# Altium schematic sheet PWM_Expander.SchDoc
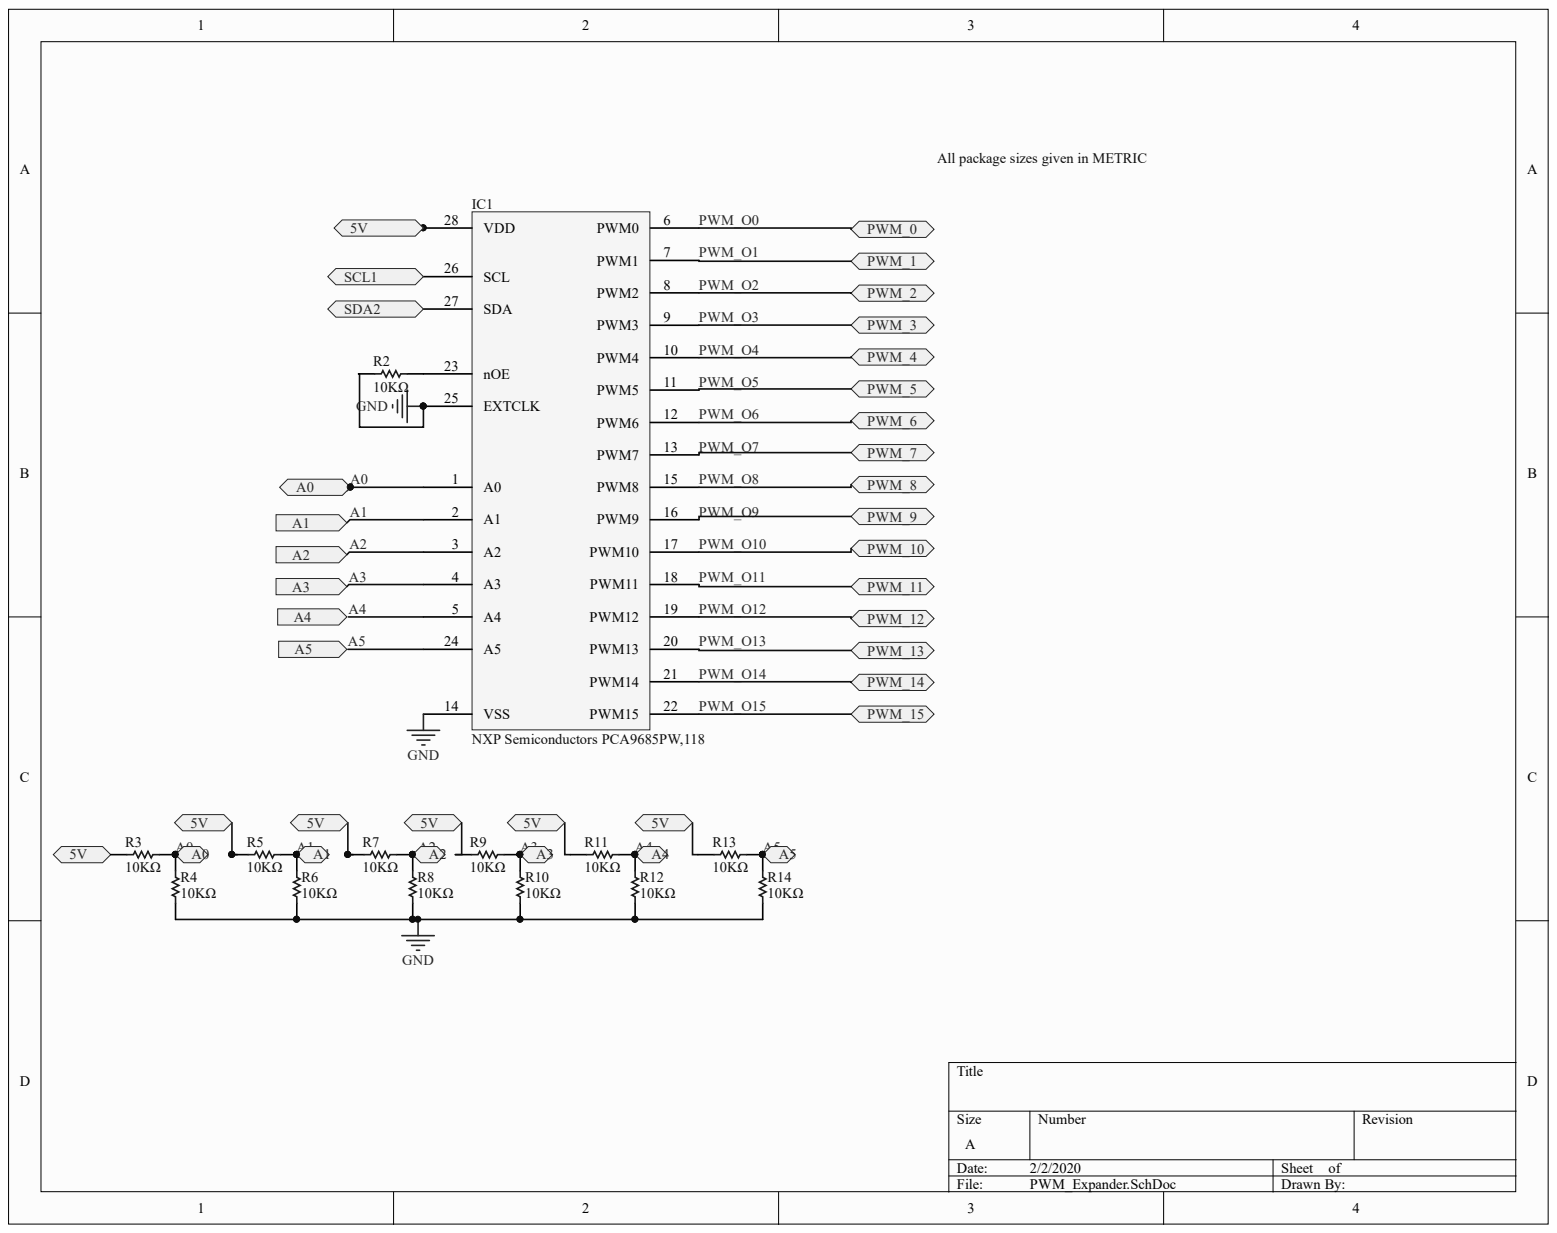
[diagram p0: PWM_Expander.SchDoc]

## Parts
IC1  NXP Semiconductors PCA9685PW,118  Manufacturer Part Number: PCA9685PW,118  [SOP28]
R2  10KΩ  [RESC3225X60X45NL20T20]
R3  10KΩ  [RESC3225X60X45NL20T20]
R4  10KΩ  [RESC3225X60X45NL20T20]
R5  10KΩ  [RESC3225X60X45NL20T20]
R6  10KΩ  [RESC3225X60X45NL20T20]
R7  10KΩ  [RESC3225X60X45NL20T20]
R8  10KΩ  [RESC3225X60X45NL20T20]
R9  10KΩ  [RESC3225X60X45NL20T20]
R10  10KΩ  [RESC3225X60X45NL20T20]
R11  10KΩ  [RESC3225X60X45NL20T20]
R12  10KΩ  [RESC3225X60X45NL20T20]
R13  10KΩ  [RESC3225X60X45NL20T20]
R14  10KΩ  [RESC3225X60X45NL20T20]

## Ports
5V, A0, A1, A2, A3, A4, A5, PWM_0, PWM_1, PWM_2, PWM_3, PWM_4, PWM_5, PWM_6, PWM_7, PWM_8, PWM_9, PWM_10, PWM_11, PWM_12, PWM_13, PWM_14, PWM_15, SCL1, SDA2

## Nets
GND: IC1.14(VSS) IC1.25(EXTCLK) R2.1 R4.2 R6.2 R8.2 R10.2 R12.2 R14.2
5V: IC1.28(VDD) R3.1 R5.1 R7.1 R9.1 R11.1 R13.1 ; port 5V
A0: IC1.1(A0) R3.2 R4.1 ; port A0
A1: IC1.2(A1) R5.2 R6.1 ; port A1
A2: IC1.3(A2) R7.2 R8.1 ; port A2
A3: IC1.4(A3) R9.2 R10.1 ; port A3
A4: IC1.5(A4) R11.2 R12.1 ; port A4
A5: IC1.24(A5) R13.2 R14.1 ; port A5
PWM_O0: IC1.6(PWM0) ; port PWM_0
PWM_O1: IC1.7(PWM1) ; port PWM_1
PWM_O2: IC1.8(PWM2) ; port PWM_2
PWM_O3: IC1.9(PWM3) ; port PWM_3
PWM_O4: IC1.10(PWM4) ; port PWM_4
PWM_O5: IC1.11(PWM5) ; port PWM_5
PWM_O6: IC1.12(PWM6) ; port PWM_6
PWM_O7: IC1.13(PWM7) ; port PWM_7
PWM_O8: IC1.15(PWM8) ; port PWM_8
PWM_O9: IC1.16(PWM9) ; port PWM_9
PWM_O10: IC1.17(PWM10) ; port PWM_10
PWM_O11: IC1.18(PWM11) ; port PWM_11
PWM_O12: IC1.19(PWM12) ; port PWM_12
PWM_O13: IC1.20(PWM13) ; port PWM_13
PWM_O14: IC1.21(PWM14) ; port PWM_14
PWM_O15: IC1.22(PWM15) ; port PWM_15
SCL1: IC1.26(SCL) ; port SCL1
SDA2: IC1.27(SDA) ; port SDA2
NetIC1_23: IC1.23(nOE) R2.2

## Library data of the parts
IC1 = CMP-d7fd1e432ce0083a-4: PCA9685 Series 5.5V 25 mA 1 MHz 12-bit PWM Fm+I2C-Bus LED Controller - TSSOP-28; Component Kind: Standard; Library Name: CommunityVault; Mounting Style: Surface Mount; Packaging: Tape & Reel (TR); RoHS: Compliant
R2-R14 = CMP-1860323-2: RES SMD 10 OHM 1% 1/2W 1210; Manufacturer Part Number: CRCW121010R0FKEA; Lead-Free Status: Lead Free; Power Rating: 0.5 W; Resistance: 10.0 Ω; Voltage Rating (DC): 200.0 V; Case/Package: 1210; Component Kind: Standard; Library Name: CommunityVault; Mounting Style: Surface Mount; Number of Pins: 2; OctopartID: 62eca9b9d586bd2b; Octopart Url: https://octopart.com/crcw121010r0fkea-vishay-39817740; Packaging: Cut Tape (CT); REACH SVHC Compliance: No SVHC; Resistance Tolerance: ±1%; RoHS: Compliant; Size-Height: 0.00055 m; Size-Length: 0.0032 m; Size-Width: 0.0025 m
